# Altium schematic sheet H-Bridge_DC_Motor.SchDoc
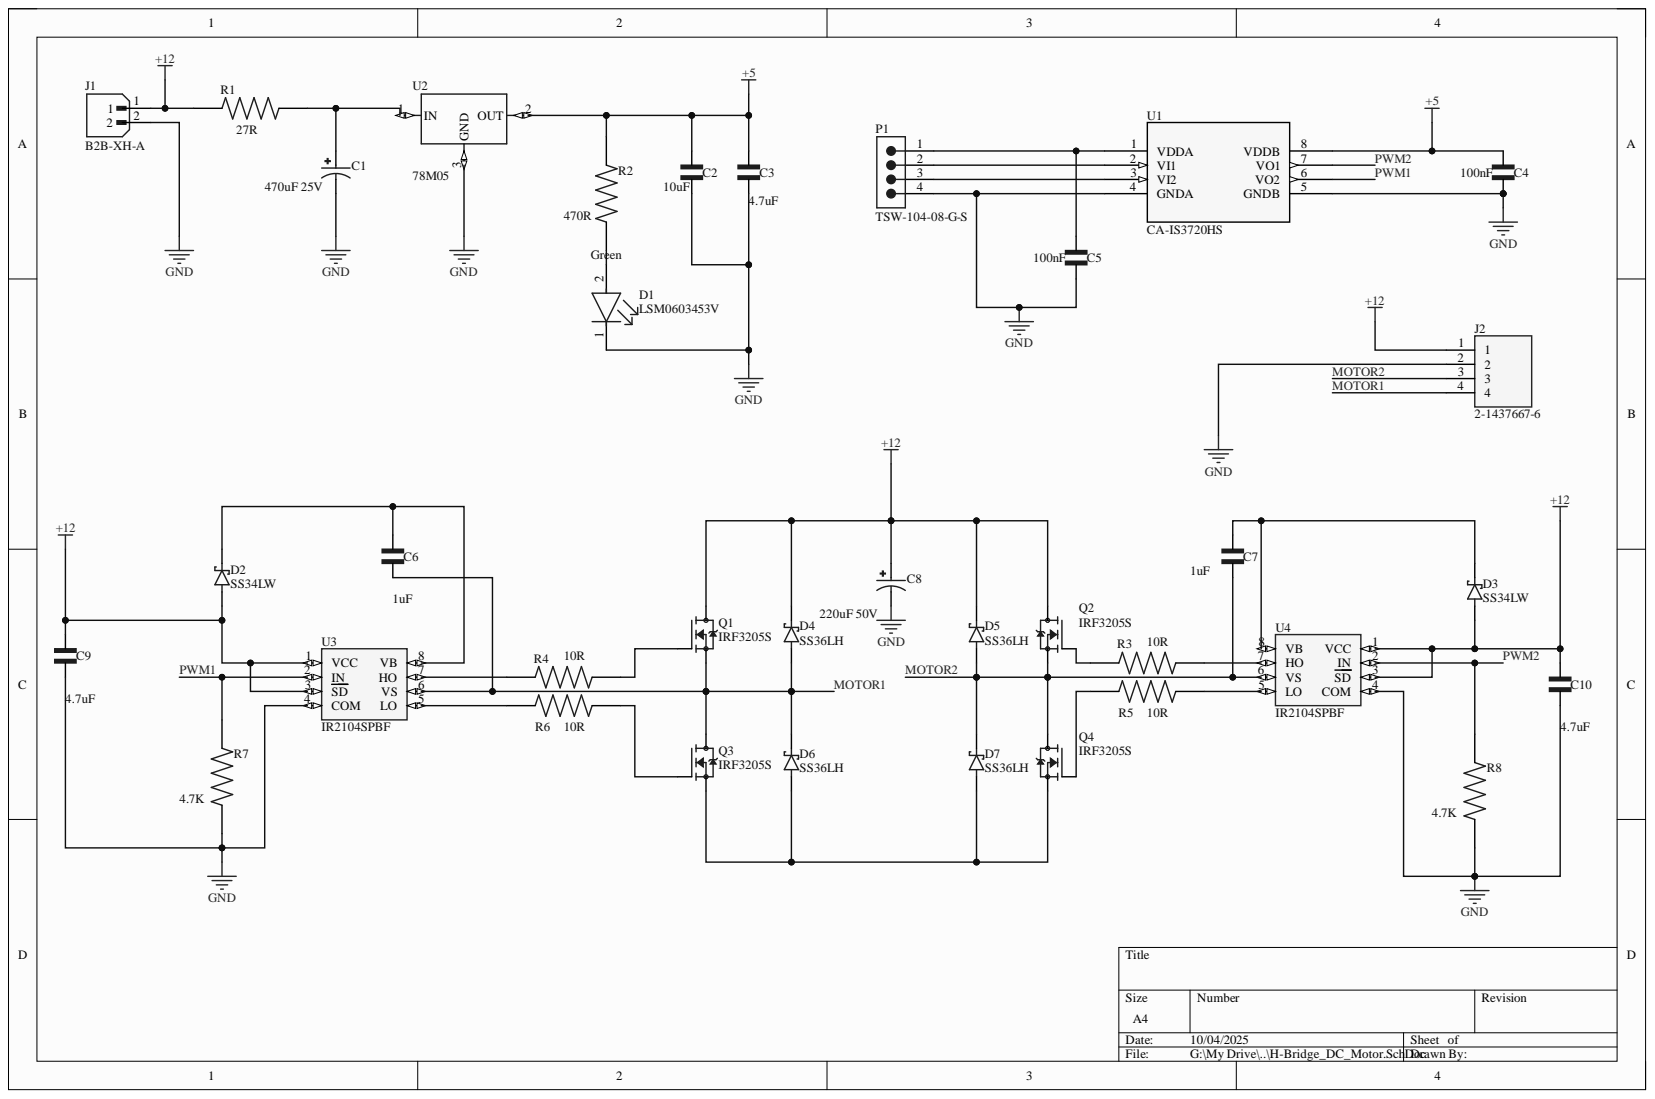
[diagram p0: H-Bridge_DC_Motor.SchDoc]

## Parts
C1  value 470uF 25V  [CAP_470uf_25V_SMD]  (hidden comment: EEEFN1E471UP)
C2  value 10uF  [CAP_0603]  (hidden comment: VJ0402D0R1BXCAP)
C3  value 4.7uF  [CAP_0603]  (hidden comment: VJ0402D0R1BXCAP)
C4  value 100nF  [CAP_0603]  (hidden comment: VJ0402D0R1BXCAP)
C5  value 100nF  [CAP_0603]  (hidden comment: VJ0402D0R1BXCAP)
C6  value 1uF  [CAP_0603]  (hidden comment: VJ0402D0R1BXCAP)
C7  value 1uF  [CAP_0603]  (hidden comment: VJ0402D0R1BXCAP)
C8  value 220uF 50V  [CAP_470uf_25V_SMD]  (hidden comment: EEEFN1E471UP)
C9  value 4.7uF  [CAP_0603]  (hidden comment: VJ0402D0R1BXCAP)
C10  value 4.7uF  [CAP_0603]  (hidden comment: VJ0402D0R1BXCAP)
D1  LSM0603453V  Color: Green  [FP-LSM0603453V-MFG]
D2  SS34LW  [SS34LW]
D3  SS34LW  [SS34LW]
D4  SS36LH  [SS36LH]
D5  SS36LH  [SS36LH]
D6  SS36LH  [SS36LH]
D7  SS36LH  [SS36LH]
J1  B2B-XH-A  [JST_B2B-XH-A]
J2  2-1437667-6  [TE_2-1437667-6]
P1  TSW-104-08-G-S  [SMTC-TSW-104-08-X-S]
Q1  IRF3205S  [IRF3205S]
Q2  IRF3205S  [IRF3205S]
Q3  IRF3205S  [IRF3205S]
Q4  IRF3205S  [IRF3205S]
R1  value 27R  [RES_0805]  (hidden comment: RES_1206)
R2  value 470R  [Res_0603]  (hidden comment: RES_1206)
R3  value 10R  [Res_0603]  (hidden comment: RES_1206)
R4  value 10R  [Res_0603]  (hidden comment: RES_1206)
R5  value 10R  [Res_0603]  (hidden comment: RES_1206)
R6  value 10R  [Res_0603]  (hidden comment: RES_1206)
R7  value 4.7K  [Res_0603]  (hidden comment: RES_1206)
R8  value 4.7K  [Res_0603]  (hidden comment: RES_1206)
U1  CA-IS3720HS  [CA-IS3720HS]
U2  78M05  [78M05]
U3  IR2104SPBF  [IR2104SPBF]
U4  IR2104SPBF  [IR2104SPBF]

## Nets
+5: C2.2 C3.2 C4.2 R2.1 U1.8(VDDB) U2.2
+12: C8.1 C9.2 C10.2 D2.A D3.A D4.C D5.C J1.1 J2.1 Q1.2 Q2.2 R1.1 U3.1(VCC) U3.3(SD) U4.1(VCC) U4.3(SD)
GND: C1.2 C2.1 C3.1 C4.1 C5.1 C8.2 C9.1 C10.1 D1.1 J1.2 J2.2 P1.4 R7.2 R8.2 U1.4(GNDA) U1.5(GNDB) U2.3 U3.4(COM) U4.4(COM)
MOTOR1: C6.1 D4.A D6.C J2.4 Q1.3 Q3.2 U3.6(VS)
MOTOR2: C7.1 D5.A D7.C J2.3 Q2.3 Q4.2 U4.6(VS)
PWM1: R7.1 U1.6(VO2) U3.2(IN)
PWM2: R8.1 U1.7(VO1) U4.2(IN)
NetC1_1: C1.1 R1.2 U2.1
NetC5_2: C5.2 P1.1 U1.1(VDDA)
NetC6_2: C6.2 D2.C U3.8(VB)
NetC7_2: C7.2 D3.C U4.8(VB)
NetD1_2: D1.2 R2.2
NetD6_A: D6.A D7.A Q3.3 Q4.3
NetP1_2: P1.2 U1.2(VI1)
NetP1_3: P1.3 U1.3(VI2)
NetQ1_1: Q1.1 R4.2
NetQ2_1: Q2.1 R3.1
NetQ3_1: Q3.1 R6.2
NetQ4_1: Q4.1 R5.1
NetR3_2: R3.2 U4.7(HO)
NetR4_1: R4.1 U3.7(HO)
NetR5_2: R5.2 U4.5(LO)
NetR6_1: R6.1 U3.5(LO)

## Library data of the parts
C1 = EEEFN1E471UP: CAP ALUM 470UF 20% 25V SMD; MF: Panasonic; Check_prices: https://www.snapeda.com/parts/EEEFN1E471UP/Panasonic/view-part/?ref=eda; Component Kind: Standard; Library Name: CAP_470uf_25V_SMD.IntLib; MP: EEEFN1E471UP; Package: RADIAL-2 Panasonic; SnapEDA_Link: https://www.snapeda.com/parts/EEEFN1E471UP/Panasonic/view-part/?ref=snap
C2-C7 C9 C10 = VJ0402D0R1BXCAP: 0.1 pF Â±0.1pF 200V Ceramic Capacitor C0G, NP0 0402 (1005 Metric); MF: Vishay Vitramon; Check_prices: https://www.snapeda.com/parts/VJ0402D0R1BXCAP/Vishay/view-part/?ref=eda; Component Kind: Standard; Library Name: CAP_SMD_NON.IntLib; MP: VJ0402D0R1BXCAP; Package: 1005 Vishay Vitramon; SnapEDA_Link: https://www.snapeda.com/parts/VJ0402D0R1BXCAP/Vishay/view-part/?ref=snap
C8 = EEEFN1E471UP: CAP ALUM 470UF 20% 25V SMD; MF: Panasonic; Value: 220uF 50V; Check_prices: https://www.snapeda.com/parts/EEEFN1E471UP/Panasonic/view-part/?ref=eda; Component Kind: Standard; Library Name: CAP_470uf_25V_SMD.IntLib; MP: EEEFN1E471UP; Package: RADIAL-2 Panasonic; SnapEDA_Link: https://www.snapeda.com/parts/EEEFN1E471UP/Panasonic/view-part/?ref=snap
D1 = CMP-00731-94024739-1: LSM0603453V LED SM 0603 3V -/1000/-mcd Green; Forward Current: 20mA; Forward Voltage: 2.3V; Luminous Intensity: 120mcd; Power Dissipation: 60mW; Reverse Voltage: 5V; Value: 3V SMD 0603 Green LED; Viewing Angle: 120°; Wavelength: 576nm; Case/Package: 0603; Component Kind: Standard; Height: 550µm; Library Name: Altium Content Vault; Max Operating Temperature: 85°C; Min Operating Temperature: -40°C; NexarPartId: 94024739; Schedule B: 8541402000, 8541406050
D2 D3 = SS34LW: 3A, 40V, Schottky Rectifier; MF: Taiwan Semiconductor; Check_prices: https://www.snapeda.com/parts/SS34LW/taiwan/view-part/?ref=eda; Component Kind: Standard; Library Name: SS34LW.IntLib; MP: SS34LW; Package: SOD-123W-2 Taiwan Semiconductor; SnapEDA_Link: https://www.snapeda.com/parts/SS34LW/taiwan/view-part/?ref=snap; Value: SS34LW
D4-D7 = SS36LH: 3A, 60V, Schottky Rectifier; MF: Taiwan Semiconductor; Availability: In Stock; Check_prices: https://www.snapeda.com/parts/SS36LH/Taiwan+Semiconductor/view-part/?ref=eda; Component Kind: Standard; Library Name: SS36LH.IntLib; MP: SS36LH; Package: SUB SMA-2 Taiwan Semiconductor; Price: None; Purchase-URL: https://pricing.snapeda.com/search/part/SS36LH/?ref=eda; SnapEDA_Link: https://www.snapeda.com/parts/SS36LH/Taiwan+Semiconductor/view-part/?ref=snap; Value: SS36LH
J1 = B2B-XH-A: MF: {'manufacturer': u'JST Sales America Inc.'}; Availability: Unavailable; Component Kind: Standard; Library Name: B2B-XH-A.IntLib; MP: B2B-XH-A; Package: None; Price: None; Value: B2B-XH-A
J2 = 2-1437667-6: Conn; Term Strip; Barrier; 4Pole; 8.26mmPitch; SnglRow; 22-12; Series 4DB; 20A; 150V; MF: TE Connectivity; Check_prices: https://www.snapeda.com/parts/2-1437667-6/TE+Connectivity/view-part/?ref=eda; Component Kind: Standard; EU_RoHS_Compliance: Compliant; Library Name: Conn Term Strip Barrier 4Pole.IntLib; MP: 2-1437667-6; Package: None; SnapEDA_Link: https://www.snapeda.com/parts/2-1437667-6/TE+Connectivity/view-part/?ref=snap; Value: 2-1437667-6
P1 = CMP-1024-00357-1: 0.025" SQ Post Header, Through-hole, Vertical, -55 to 125 degC, 2.54 mm Pitch, 4-Pin, Male, RoHS; Manufacturer: Samtec; Pitch: 2.54 mm; Component Kind: Standard; ComponentLink1Description: Manufacturer URL; ComponentLink1URL: http://www.samtec.com; ComponentLink2Description: Datasheet; ComponentLink2URL: http://www.samtec.com/documents/webfiles/pdf/TSW_TH.PDF; ComponentLink3Description: Package Specification; ComponentLink3URL: http://www.samtec.com/documents/webfiles/cpdf/TSW-XXX-XX-XXX-X-XX-XXX-MKT.pdf; DatasheetVersion: F-214; Library Name: Altium Content Vault; Mounting Technology: Through-hole; Orientation: Vertical; PackageDescription: .025[0.64] SQ. Post Terminal Strip Assembly; PackageReference: TSW-104-08-X-S; PackageVersion: DM, 8/1988; PartNumber: TSW-104-08-G-S; RoHS: True; Temperature Range: -55 to 125 degC; Value: TSW-104-08-G-S
Q1-Q4 = IRF3205S: N-Channel 55 V 110A (Tc) 200W (Tc) Surface Mount D2PAK; MF: Infineon Technologies; Availability: Not in stock; Check_prices: https://www.snapeda.com/parts/IRF3205S/Infineon+Technologies/view-part/?ref=eda; Component Kind: Standard; Library Name: IRF3205S.IntLib; MP: IRF3205S; Package: D2PAK Infineon / IR; Price: None; SnapEDA_Link: https://www.snapeda.com/parts/IRF3205S/Infineon+Technologies/view-part/?ref=snap; Value: IRF3205S
R1-R8 = RES_1206: RES SMD 2.2 OHM 5% 1/2W 1206; MF: Panasonic; MP: ERJ8BQJ2R2V; Availability: In Stock; Check_prices: https://www.snapeda.com/parts/ERJ8BQJ2R2V/Panasonic/view-part/?ref=eda; Component Kind: Standard; Library Name: RES_SMD.IntLib; Package: 3216-2 Panasonic; Price: None; Purchase-URL: https://pricing.snapeda.com/search/part/ERJ8BQJ2R2V/?ref=eda; SnapEDA_Link: https://www.snapeda.com/parts/ERJ8BQJ2R2V/Panasonic/view-part/?ref=snap
U1 = CA-IS3720HS: No Description Available; Manufacturer_Name: Chipanalog; Component Kind: Standard; Library Name: CA-IS3720HS.IntLib; Manufacturer_Part_Number: CA-IS3720HS; RefDes: RefDes; Type: Digital Isolators; Value: CA-IS3720HS; Copyright: Copyright (C) 2025 Ultra Librarian. All rights reserved.
U2 = 78M05: Linear Voltage Regulator IC Positive Fixed 1 Output 1A TO-252 (DPAK); MF: UMW; Check_prices: https://www.snapeda.com/parts/78M05/Umwelt+Sensor+Teknik/view-part/?ref=eda; Component Kind: Standard; Library Name: 78M05.IntLib; MP: 78M05; Package: None; SnapEDA_Link: https://www.snapeda.com/parts/78M05/Umwelt+Sensor+Teknik/view-part/?ref=snap; Value: 78M05
U3 U4 = IR2104SPBF: Half-Bridge Gate Driver IC Non-Inverting 8-SOIC; MF: Infineon; Check_prices: https://www.snapeda.com/parts/IR2104SPBF/Infineon/view-part/?ref=eda; Component Kind: Standard; Library Name: IR2104SPBF.IntLib; MP: IR2104SPBF; Package: SOIC-8 Infineon; SnapEDA_Link: https://www.snapeda.com/parts/IR2104SPBF/Infineon/view-part/?ref=snap; Value: IR2104SPBF
